# Altium schematic sheet GA-RELE-B.SchDoc(GA-RELE-B)
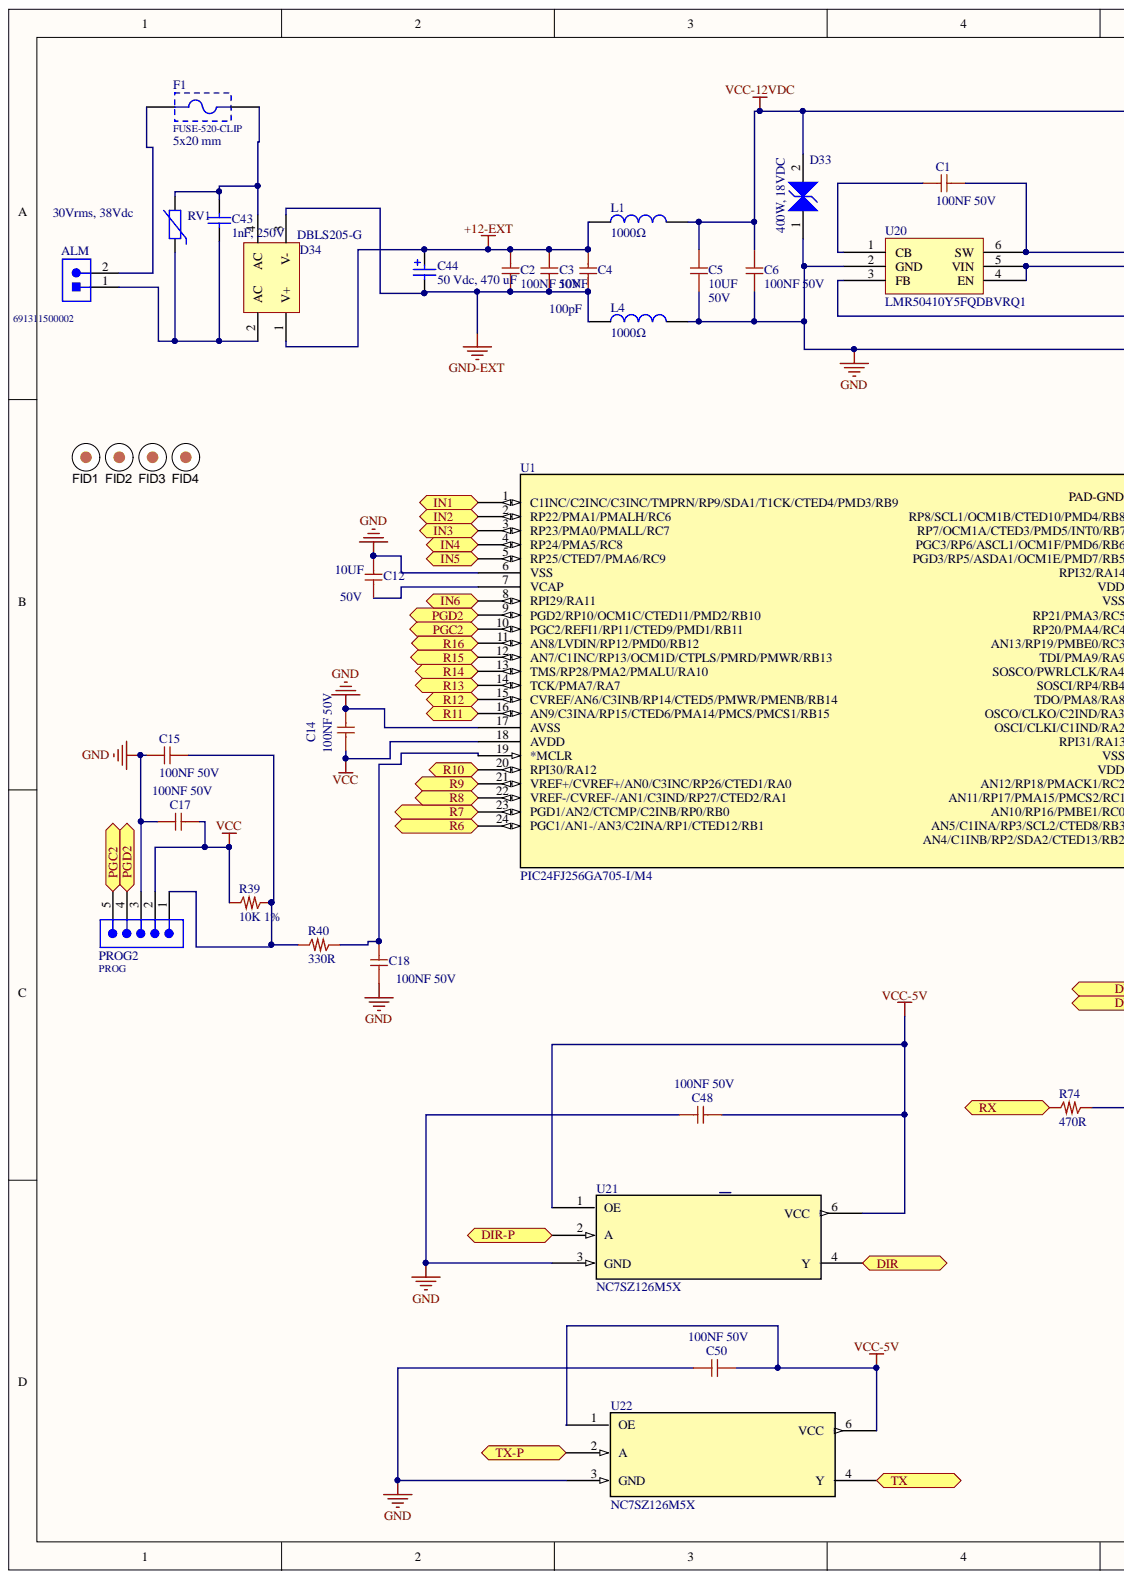
[diagram p0: GA-RELE-B.SchDoc, left]
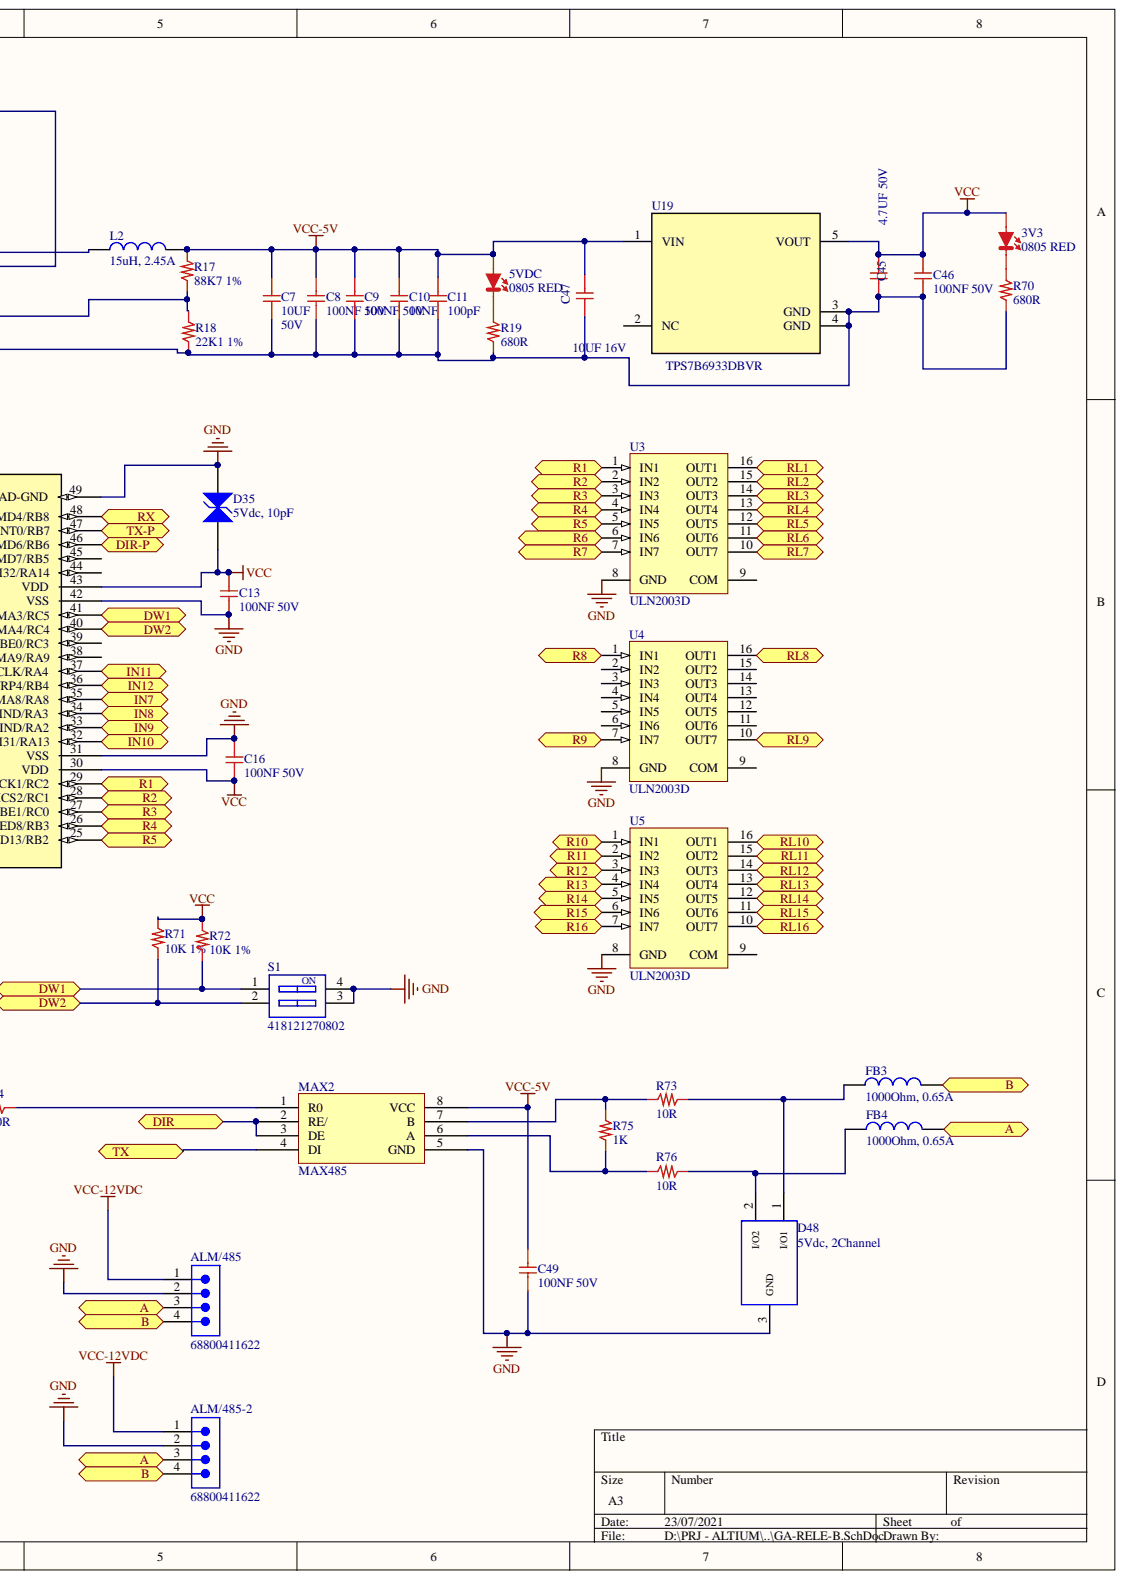
[diagram p1: GA-RELE-B.SchDoc, right]

## Parts
3V3  0805 RED  [0805_Red]
5VDC  0805 RED  [0805_Red]
ALM  691311500002  [691311500002]
ALM/485  68800411622  [68800411622]
ALM/485-2  68800411622  [68800411622]
C1  100NF 50V  [0603_c]
C2  100NF 50V  [0603_c]
C3  10NF  [0603_c]
C4  100pF  [0603_c]
C5  10UF  Tensione: 50V  [1206_C]
C6  100NF 50V  [0603_c]
C7  10UF  Tensione: 50V  [1206_C]
C8  100NF 50V  [0603_c]
C9  100NF 50V  [0603_c]
C10  10NF  [0603_c]
C11  100pF  [0603_c]
C12  10UF  Tensione: 50V  [1206_C]
C13  100NF 50V  [0603_c]
C14  100NF 50V  [0603_c]
C15  100NF 50V  [0603_c]
C16  100NF 50V  [0603_c]
C17  100NF 50V  [0603_c]
C18  100NF 50V  [0603_c]
C43  1nF, 250V  [WCAP-CSSA_1808_H=2mm]
C44  50 Vdc, 470 uF  value 470 uF  [WCAP-ATLI_13x20x5]
C45  4.7UF 50V  [0805_C]
C46  100NF 50V  [0603_c]
C47  10UF 16V  [0805_C]
C48  100NF 50V  [0603_c]
C49  100NF 50V  [0603_c]
C50  100NF 50V  [0603_c]
D33  400W, 18VDC  [WE-TVSP_DO-214AC_Bidirectional]
D34  DBLS205-G  [DBLS205-G]
D35  5Vdc, 10pF  Capacitance: 10pF  [WE-VE_0603]
D48  5Vdc, 2Channel  [WE-TVS_Standard_SOT23-3L]
F1  FUSE-520-CLIP  Fuse Size: 5x20 mm  [FUSE-520-CLIP]
FB3  1000Ohm, 0.65A  Rated Current: 0.65A  [WE-CBF_0603_Wide Band]
FB4  1000Ohm, 0.65A  Rated Current: 0.65A  [WE-CBF_0603_Wide Band]
FID1  Fiducial  [Fiducial10-20]  (value not drawn)
FID2  Fiducial  [Fiducial10-20]  (value not drawn)
FID3  Fiducial  [Fiducial10-20]  (value not drawn)
FID4  Fiducial  [Fiducial10-20]  (value not drawn)
L1  1000Ω  [WE-CBF_0805_Wide Band]
L2  15uH, 2.45A  Rated Current: 2.45A  [WE-LQS_6045]
L4  1000Ω  [WE-CBF_0805_Wide Band]
MAX2  MAX485  [SO-8]
PROG2  PROG  [PROG1 - TR]
R17  88K7 1%  [0603_R]
R18  22K1 1%  [0603_R]
R19  680R  [0603_R]
R39  10K 1%  [0603_R]
R40  330R  [0603_R]
R70  680R  [0603_R]
R71  10K 1%  [0603_R]
R72  10K 1%  [0603_R]
R73  10R  [0805_R]
R74  470R  [0603_R]
R75  1K  [0805_R]
R76  10R  [0805_R]
RV1  30Vrms, 38Vdc  DC Operating Voltage: 38Vdc  [WE-VD_7mm_820573001]
S1  418121270802  [418121270802]
U1  PIC24FJ256GA705-I/M4  [UQFN48_PTX-M4_MCH-L]  (value not drawn)
U3  ULN2003D  [SO16_N]
U4  ULN2003D  [SO16_N]
U5  ULN2003D  [SO16_N]
U19  TPS7B6933DBVR  [DBV0005A_N]
U20  LMR50410Y5FQDBVRQ1  [SOT23-6_N]
U21  NC7SZ126M5X  [SOT23-5_N]
U22  NC7SZ126M5X  [SOT23-5_N]

## Ports
A, B, DIR, DIR-P, DW1, DW2, IN1, IN2, IN3, IN4, IN5, IN6, IN7, IN8, IN9, IN10, IN11, IN12, PGC2, PGD2, R1, R2, R3, R4, R5, R6, R7, R8, R9, R10, R11, R12, R13, R14, R15, R16, RL1, RL2, RL3, RL4, RL5, RL6, RL7, RL8, RL9, RL10, RL11, RL12, RL13, RL14, RL15, RL16, RX, TX, TX-P

## Nets
+12-EXT: C2.1 C3.1 C4.1 C44.1 D34.1(V+) L1.1
GND: ALM/485.2 ALM/485-2.2 C5.2 C6.2 C7.2 C8.2 C9.2 C10.2 C11.2 C12.1 C13.2 C14.1 C15.1 C16.1 C17.1 C18.2 C45.2 C46.1 C47.2 C48.1 C49.1 C50.1 D33.1 D35.2 D48.3 L4.2 MAX2.5(GND) PROG2.3 R18.1 R19.2 R70.2 S1.3 S1.4 U1.6(VSS) U1.17(AVSS) U1.31(VSS) U1.42(VSS) U1.49(PAD-GND) U3.8(GND) U4.8(GND) U5.8(GND) U19.3(GND) U19.4(GND) U20.2(GND) U21.3(GND) U22.3(GND)
GND-EXT: C2.2 C3.2 C4.2 C44.2 D34.3(V-) L4.1
VCC: 3V3.A C13.1 C14.2 C16.2 C17.2 C45.1 C46.2 D35.1 PROG2.2 R39.1 R71.2 R72.2 U1.18(AVDD) U1.30(VDD) U1.43(VDD) U19.5(VOUT)
VCC-5V: 5VDC.A C7.1 C8.1 C9.1 C10.1 C11.1 C47.1 C48.2 C49.2 C50.2 L2.2 MAX2.8(VCC) R17.1 U19.1(VIN) U21.1(OE) U21.6(VCC) U22.1(OE) U22.6(VCC)
VCC-12VDC: ALM/485.1 ALM/485-2.1 C5.1 C6.1 D33.2 L1.2 U20.4(EN) U20.5(VIN)
A: ALM/485.3 ALM/485-2.3 FB4.2 ; port A
B: ALM/485.4 ALM/485-2.4 FB3.2 ; port B
DIR: MAX2.2(RE/) MAX2.3(DE) U21.4(Y) ; port DIR
DIR-P: U1.46(PGC3/RP6/ASCL1/OCM1F/PMD6/RB6) U21.2(A) ; port DIR-P
DW1: R72.1 S1.1 U1.41(RP21/PMA3/RC5) ; port DW1
DW2: R71.1 S1.2 U1.40(RP20/PMA4/RC4) ; port DW2
IN1: U1.1(C1INC/C2INC/C3INC/TMPRN/RP9/SDA1/T1CK/CTED4/PMD3/RB9) ; port IN1
IN2: U1.2(RP22/PMA1/PMALH/RC6) ; port IN2
IN3: U1.3(RP23/PMA0/PMALL/RC7) ; port IN3
IN4: U1.4(RP24/PMA5/RC8) ; port IN4
IN5: U1.5(RP25/CTED7/PMA6/RC9) ; port IN5
IN6: U1.8(RPI29/RA11) ; port IN6
IN7: U1.35(TDO/PMA8/RA8) ; port IN7
IN8: U1.34(OSCO/CLKO/C2IND/RA3) ; port IN8
IN9: U1.33(OSCI/CLKI/C1IND/RA2) ; port IN9
IN10: U1.32(RPI31/RA13) ; port IN10
IN11: U1.37(SOSCO/PWRLCLK/RA4) ; port IN11
IN12: U1.36(SOSCI/RP4/RB4) ; port IN12
PGC2: PROG2.5 U1.10(PGC2/REFI1/RP11/CTED9/PMD1/RB11) ; port PGC2
PGD2: PROG2.4 U1.9(PGD2/RP10/OCM1C/CTED11/PMD2/RB10) ; port PGD2
R1: U1.29(AN12/RP18/PMACK1/RC2) U3.1(IN1) ; port R1
R2: U1.28(AN11/RP17/PMA15/PMCS2/RC1) U3.2(IN2) ; port R2
R3: U1.27(AN10/RP16/PMBE1/RC0) U3.3(IN3) ; port R3
R4: U1.26(AN5/C1INA/RP3/SCL2/CTED8/RB3) U3.4(IN4) ; port R4
R5: U1.25(AN4/C1INB/RP2/SDA2/CTED13/RB2) U3.5(IN5) ; port R5
R6: U1.24(PGC1/AN1-/AN3/C2INA/RP1/CTED12/RB1) U3.6(IN6) ; port R6
R7: U1.23(PGD1/AN2/CTCMP/C2INB/RP0/RB0) U3.7(IN7) ; port R7
R8: U1.22(VREF-/CVREF-/AN1/C3IND/RP27/CTED2/RA1) U4.1(IN1) ; port R8
R9: U1.21(VREF+/CVREF+/AN0/C3INC/RP26/CTED1/RA0) U4.7(IN7) ; port R9
R10: U1.20(RPI30/RA12) U5.1(IN1) ; port R10
R11: U1.16(AN9/C3INA/RP15/CTED6/PMA14/PMCS/PMCS1/RB15) U5.2(IN2) ; port R11
R12: U1.15(CVREF/AN6/C3INB/RP14/CTED5/PMWR/PMENB/RB14) U5.3(IN3) ; port R12
R13: U1.14(TCK/PMA7/RA7) U5.4(IN4) ; port R13
R14: U1.13(TMS/RP28/PMA2/PMALU/RA10) U5.5(IN5) ; port R14
R15: U1.12(AN7/C1INC/RP13/OCM1D/CTPLS/PMRD/PMWR/RB13) U5.6(IN6) ; port R15
R16: U1.11(AN8/LVDIN/RP12/PMD0/RB12) U5.7(IN7) ; port R16
RL1: U3.16(OUT1) ; port RL1
RL2: U3.15(OUT2) ; port RL2
RL3: U3.14(OUT3) ; port RL3
RL4: U3.13(OUT4) ; port RL4
RL5: U3.12(OUT5) ; port RL5
RL6: U3.11(OUT6) ; port RL6
RL7: U3.10(OUT7) ; port RL7
RL8: U4.16(OUT1) ; port RL8
RL9: U4.10(OUT7) ; port RL9
RL10: U5.16(OUT1) ; port RL10
RL11: U5.15(OUT2) ; port RL11
RL12: U5.14(OUT3) ; port RL12
RL13: U5.13(OUT4) ; port RL13
RL14: U5.12(OUT5) ; port RL14
RL15: U5.11(OUT6) ; port RL15
RL16: U5.10(OUT7) ; port RL16
RX: R74.1 U1.48(RP8/SCL1/OCM1B/CTED10/PMD4/RB8) ; port RX
TX: MAX2.4(DI) U22.4(Y) ; port TX
TX-P: U1.47(RP7/OCM1A/CTED3/PMD5/INT0/RB7) U22.2(A) ; port TX-P
Net3V3_K: 3V3.K R70.1
Net5VDC_K: 5VDC.K R19.1
NetALM_1: ALM.1 C43.1 D34.2(AC) RV1.1
NetALM_2: ALM.2 F1.1
NetC1_1: C1.1 U20.1(CB)
NetC1_2: C1.2 L2.1 U20.6(SW)
NetC12_2: C12.2 U1.7(VCAP)
NetC15_2: C15.2 PROG2.1 R39.2 R40.2
NetC18_1: C18.1 R40.1 U1.19(*MCLR)
NetC43_2: C43.2 D34.4(AC) F1.2 RV1.2
NetD48_1: D48.1 FB3.1 R73.2
NetD48_2: D48.2 FB4.1 R76.2
NetMAX2_1: MAX2.1(R0) R74.2
NetMAX2_6: MAX2.6(A) R75.1 R76.1
NetMAX2_7: MAX2.7(B) R73.1 R75.2
NetR17_2: R17.2 R18.2 U20.3(FB)
N00004:

Not connected: U1 38(TDI/PMA9/RA9) 39(AN13/RP19/PMBE0/RC3) 44(RPI32/RA14) 45(PGD3/RP5/ASDA1/OCM1E/PMD7/RB5); U3 9(COM); U4 2(IN2) 3(IN3) 4(IN4) 5(IN5) 6(IN6) 9(COM) 11(OUT6) 12(OUT5) 13(OUT4) 14(OUT3) 15(OUT2); U5 9(COM); U19 2(NC)

## Library data of the parts
3V3 5VDC = LED_ROSSO_0805: LED Rosso 0805; V: 2.0V; Produttore: WE; Luminosità: 150mcd; Cod. Produttore: 150080RS75000; Cod. Interno: LEDS 2AC080RS7500; Component Kind: Standard; Library Name: DiodiLED.SchLib
ALM = 691311500002: Serie 311 - 5.08mm Open Vertical PCB Header WR-TBL, 2 pin; Pin Number: 2; Gender: Male; Published Date: July 2018; Pitch: 5.08 mm; Part Number: 691311500002; Packaging: Box; Operating Temperature: -40 to 105 degC; Mounting Technology: THT; Library Name: Pluggable PCB System WR-TBL_5.08 mm.SchLib; ComponentLink2URL: http://katalog.we-online.de/em/datasheet/6913115000xx.pdf; ComponentLink2Description: Datasheet; ComponentLink1URL: http://www.we-online.de; ComponentLink1Description: Manufacturer; Component Kind: Standard; Publisher: Wurth Elektronik
ALM/485 ALM/485-2 = 68800411622: WR-WTB “J” Type THT Vertical Male Shrouded Header, pitch 2.5mm, 4p; Working Voltage: 250VAC; Withstanding Voltage: 800VAC; Rated Current: 3A; Pin Number: 4; Contact Resistance: 20mOhm; Type: Vertical; Published Date: January 2020; Pitch: 2.5mm; Part Number: 68800411622; Packaging: Bag; Operating Temperature: -25 to +85degC; Mounting Technology: THT; Library Name: WR-WTB_2.50mm.SchLib; Gender: Male; ComponentLink2URL: https://www.we-online.com/catalog/datasheet/68800411622.pdf; ComponentLink2Description: Datasheet; ComponentLink1URL: http://www.we-online.de; ComponentLink1Description: Manufacturer; Component Kind: Standard; Publisher: Wurth Elektronik
C1 C2 C6 C8 C9 C13-C18 C46 C48-C50 = 100NF 50V: Cap X7R 100nF 50V Flex Terminal; Produttore: Samsung; Cod. Produttore: CL10B104KB8SFNC; Cod. Interno: COCS50665050104; Library Name: CapSMD0603.SchLib; Component Kind: Standard; Cod. DigiKey: 1276-1936-2-ND
C3 C10 = 10NF 50V: Cap X7R 10nF 50V; Produttore: Samsung; Cod. Produttore: CL05B103KB5NNNC; Cod. Interno: COCS 556655000103; Library Name: CapSMD0603.SchLib; Component Kind: Standard; Cod. DigiKey: 1276-1028-2-ND
C4 C11 = 100pF 50V: Cap X7R 100pF 50V; Produttore: ??; Cod. Interno: COCS??; Library Name: CapSMD0603.SchLib; Component Kind: Standard; Cod. Produttore: ??
C5 C7 C12 = 10UF 50V: Cap X5R 10UF 50V; Produttore: MURATA; Cod. Produttore: GRM31CR61H106KA12L; Temperature Range: Da -55°C a +125°C; Library Name: CapSMD1206.SchLib; Component Kind: Standard; Cod. Farnell: 2666583
C43 = 885362210017: WCAP-CSSA X&Y Safety Ceramic Capacitors, size 1808, 1nF, 250VAC; Technical Reference: X7R1808102KB50IFCT10000; Safety Class: X2; Isolation Resistance: 10GOhm; Dissipation Factor: 2.5%; Ceramic Type: X7R; Size: 1808; Rated Voltage: 250VAC; Published Date: August 2019; Part Number: 885362210017; Packaging: Tape and Reel; Operating Temperature: -55 to +125degC; Mounting Technology: SMD; Library Name: WCAP-CSSA_1808.SchLib; Height: 2mm +/-0.2mm; ComponentLink2URL: https://katalog.we-online.com/pbs/datasheet/885362210017.pdf; ComponentLink2Description: Datasheet; ComponentLink1URL: http://www.we-online.de; ComponentLink1Description: Manufacturer; Component Kind: Standard; Capacitance: 1nF; Publisher: Wurth Elektronik
C44 = 860080678019: WCAP-ATLI Aluminum Electrolytic Capacitors, 13x20mm, 50 Vdc, 470 uF; Value: 470 uF; Rated Voltage: 50 Vdc; Size: 13x20mm; Published Date: Sep. 2017; Part Number: 860080678019; Operating Temperature: -55 °C up to +105 °C; Life Cycle: 5000h; Library Name: WCAP-ATLI.SchLib; ComponentLink2URL: http://katalog.we-online.com/pbs/datasheet/860080678019.pdf; ComponentLink2Description: Datasheet; ComponentLink1URL: http://www.we-online.de; ComponentLink1Description: Manufacturer; Component Kind: Standard; Publisher: Wurth Elektronik
C45 = 4.7UF 50V: Cap X5R 4.7UF 50V; Produttore: TDK; Cod. Produttore: C2012X5R1H475K; Cod. Interno: COCS517065000475; Temperature Range: Da -55°C a +105°C; Library Name: CapSMD0805.SchLib; Component Kind: Standard
C47 = 10UF 16V: Cap X5R 10UF 16V; Produttore: TDK; Cod. Produttore: C2012X6S1C106K125AC; Cod. Interno: COCS517061600106; Temperature Range: Da -55°C a +105°C; Library Name: CapSMD0805.SchLib; Component Kind: Standard; Cod. DigiKey: 445-7611-2-ND
D33 = 824501181: WE-TVSP Power TVS Diode, DO-214AC, 400W, 18VDC, Bidirectional; DC Operating Voltage: 18V; Clamping Voltage: 29.2V; (Reverse) Breakdown Voltage: 21.05V; (Forward) Peak Pulse Current: 13.7A; Steady State Power Dissipation: 400W; Size: DO-214AC; Published Date: March 2019; Polarity: Bidirectional; Part Number: 824501181; Packaging: Tape and Reel; Operating Temperature: -65 to +150degC; Mounting Technology: SMT; Library Name: WE-TVSP_DO-214AC_Bidirectional.SchLib; ComponentLink2URL: https://katalog.we-online.com/pbs/datasheet/824501181.pdf; ComponentLink2Description: Datasheet; ComponentLink1URL: http://www.we-online.de; ComponentLink1Description: Manufacturer; Component Kind: Standard; Publisher: Wurth Elektronik
D34 = DBLS205-G: Diode Bridge; Simulation: BRIDGE; Published: 24-Mar-1999; PackageReference: D-46_6A; PackageDescription: Bridge Rectifier; 4 Terminals; Body 16.8 x 16.8 mm (typ); Pitch 10.67 mm; LatestRevisionNote: Re-released for DXP Platform.; LatestRevisionDate: 17-Jul-2002; Component Kind: Standard; Publisher: Altium Limited; Library Name: HSW.SchLib
D35 = 82356050100: WE-VE ESD Suppressor, size 0603, 5Vdc, 10pF; Leakage Current: 1uA; ESD Voltage air discharge: 15kV; Clamp Voltage: 60V; Working Voltage: 5Vdc; Size: 0603; Published Date: June 2019; Part Number: 82356050100; Packaging: Tape and Reel; Operating Temperature: -40 to +85degC; Mounting Technology: SMT; Library Name: WE-VE_0603.SchLib; ComponentLink2URL: https://katalog.we-online.com/pbs/datasheet/82356050100.pdf; ComponentLink2Description: Datasheet; ComponentLink1URL: http://www.we-online.de; ComponentLink1Description: Manufacturer; Component Kind: Standard; Publisher: Wurth Elektronik
D48 = 824022: WE-TVS TVS Diode - Standard Series, SOT23-3L, 5Vdc, 2 Channel; Reverse Peak Pulse Current: 8A; Reverse Channel Leakage Current: 2.5uA; Reverse Breakdown Voltage min.: 6.1V; DC Operating Voltage: 5V; Channel Input Capacitance: 12pF; Channel: 2; Size: SOT23-3L; Published Date: June 2019; Part Number: 824022; Packaging: Tape and Reel; Operating Temperature: -55 to +125degC; Mounting Technology: SMT; Library Name: WE-TVS.SchLib; ComponentLink2URL: https://katalog.we-online.com/pbs/datasheet/824022.pdf; ComponentLink2Description: Datasheet; ComponentLink1URL: http://www.we-online.de; ComponentLink1Description: Manufacturer; Component Kind: Standard; Publisher: Wurth Elektronik
F1 = FUSE-520-CLIP: LITTELFUSE-520 Series Circuit Board Mount Fuse Clips for 5mm & 6.3mm Diameter Fuses; Part Number: 696108003002; Published Date: August 2018; Packaging: Box; Operating Temperature: -30 to 120 degC; Mounting Technology: THT; ComponentLink2URL: https://katalog.we-online.de/em/datasheet/696108003002.pdf; ComponentLink2Description: Datasheet; ComponentLink1URL: http://www.we-online.de; ComponentLink1Description: Manufacturer; Component Kind: Standard; Publisher: Wurth Elektronik; Library Name: HSW.SchLib
FB3 FB4 = 74279266: WE-CBF SMT EMI Suppression Ferrite Bead, size 0603, 1000Ohm, 0.65A; Type: Wide Band; Impedance max.: 1350Ohm; DC Resistance max.: 0.6Ohm; Size: 0603; Published Date: March 2019; Part Number: 74279266; Packaging: Tape and Reel; Operating Temperature: -55 to +125degC; Mounting Technology: SMT; Library Name: WE-CBF_0603.SchLib; Impedance@100 MHz: 1000Ohm; ComponentLink2URL: https://katalog.we-online.com/pbs/datasheet/74279266.pdf; ComponentLink2Description: Datasheet; ComponentLink1URL: http://www.we-online.de; ComponentLink1Description: Manufacturer; Component Kind: Standard; Publisher: Wurth Elektronik
FID1-FID4 = Fiducial: Fiducial mark.  There is nothing to buy or mount.; SymbolCreator: codlsc; SymbolVersion: 2009-11-4; PartNumber: N/A; PackageReference: N/A; MountType: N/A; Manufacturer: N/A; LatestRevisionNote: Added Alternate PartNumber and Alternate Manufacturer parameters to appropriate components.; LatestRevisionDate: 2015-10-18; CompType: Fiducial; Component Kind: Standard; ClassName: FiducialMark; Publisher: Texas Instruments; Library Name: HSW.SchLib
L1 L4 = WE-CBF, 74279205: WE-CBF SMD EMI Suppression Ferrite Bead, 0805, 1000Ω; Type: Wide Band; Value: 1000Ω; Size: 0805; PublishedDate: Aug 2016; PartNumber: 74279205; Library Name: WE-CBF_0805.SchLib; ComponentLink2URL: http://katalog.we-online.de/pbs/datasheet/74279205.pdf; ComponentLink2Description: Datasheet; ComponentLink1URL: http://www.we-online.de; ComponentLink1Description: Manufacturer; Component Kind: Standard; Publisher: Wurth Elektronik
L2 = 74404064150: WE-LQS SMT Power Inductor, size 6045, 15uH, 2.45A; Manufacturer: Wurth Elektronik; Self Resonant Frequency: 17MHz; Saturation Current: 3A; DC Resistance: 68mOhm; Size: 6045; Published Date: March 2020; Packaging: Tape and Reel; Operating Temperature: -40 to +125degC; Mounting Technology: SMT; Manufacturer Part Number: 74404064150; Library Name: WE-LQS_6045.SchLib; Inductance: 15uH; ComponentLink2URL: https://www.we-online.com/catalog/datasheet/74404064150.pdf; ComponentLink2Description: Datasheet; ComponentLink1URL: http://www.we-online.de; ComponentLink1Description: Manufacturer; Component Kind: Standard
PROG2 = PROG1-TR: SMT Vertical Pin Header WR-PHD, Pitch 2.54 mm, Single Row, 6 pins; Part Number: 61000618321; Working Voltage: 250 VAC; Withstanding Voltage: 500 VAC; Rated Current: 3 A; Pitch: 2.54 mm; Pin Number: 6; Gender: Male; Contact Resistance: 20 mOhm; Row Number: Single; Published Date: August 2018; Packaging: Tube; Orientation: Vertical; Operating Temperature: -40 to 125 degC; Mounting Technology: SMT; ComponentLink2URL: https://katalog.we-online.de/em/datasheet/6100xx18321.pdf; ComponentLink2Description: Datasheet; ComponentLink1URL: http://www.we-online.de; ComponentLink1Description: Manufacturer; Component Kind: Standard; Publisher: Wurth Elektronik; Library Name: HSW.SchLib
R17 = 88K7 1%: Res Film Spesso 1/16W 88K7 1%; Library Name: ResSMD0603.SchLib; Component Kind: Standard
R18 = 22K1 1%: Res Film Spesso 1/16W 22K1 1%; Produttore: ??; Library Name: ResSMD0603.SchLib; Component Kind: Standard; Cod. Produttore: ??
R19 R70 = 680R: Res Film Spesso 1/16W 680R 5%; Cod. Interno: RESS 4166556B0681; Library Name: ResSMD0603.SchLib; Component Kind: Standard
R39 R71 R72 = 10K 1%: Res Film Spesso 1/16W 10K 1%; Produttore: Panasonic; Cod. Produttore: ERJ-3EKF1002V; Cod. Interno: RESS4166356B0103; Library Name: ResSMD0603.SchLib; Component Kind: Standard
R40 = 330R: Res Film Spesso 1/16W 330R 5%; Cod. Interno: RESS4166556B0331; Library Name: ResSMD0603.SchLib; Component Kind: Standard
R73 R76 = 10R: Res Film Spesso 1/8W 10R 5%; Potenza: 1/8W 5%; Cod. Interno: RESS4170555B0100; Library Name: ResSMD0805.SchLib; Component Kind: Standard
R74 = 470R: Res Film Spesso 1/16W 470R 5%; Produttore: ??; Cod. Interno: RESS4166556B0471??; Library Name: ResSMD0603.SchLib; Component Kind: Standard; Cod. Produttore: ??
R75 = 1K: Res Film Spesso 1/2W 1K 5%; Potenza: 1/2W 5%; Cod. Interno: RESS4170555B0122??; Library Name: ResSMD0805.SchLib; Component Kind: Standard
RV1 = 820573001: WE-VD Disk Varistor, size 7mm, 30Vrms, 38Vdc; Power Dissipation: 0.02W; Energy Absorbtion: 3J; Clamping Voltage: 93V; (Reverse) Peak Pulse Current: 250A; (Reverse) Breakdown Voltage: 47V; (Channel) Input Capacitance: 1600pF; Size: 7mm; Published Date: March 2019; Part Number: 820573001; Packaging: Tape and Reel; Operating Temperature: -40 to +85degC; Mounting Technology: THT; ComponentLink2URL: https://katalog.we-online.com/pbs/datasheet/820573001.pdf; ComponentLink2Description: Datasheet; ComponentLink1URL: http://www.we-online.de; ComponentLink1Description: Manufacturer; Component Kind: Standard; AC Operating Voltage: 30Vrms; Publisher: Wurth Elektronik; Library Name: MOV_7mm.SchLib
S1 = 418121270802: SMD Dip Switch WS-DISV, Small Compact, Raised Actuator, pitch 2.54mm, 2pin; Pole Number: 2; Operation Force: 600g max.; Actuator Type: Raise Type; Published Date: April 2019; Pitch: 2.54mm; Part Number: 418121270802; Packaging: Tape and Reel; Operating Temperature: -40 to +85degC; Mounting Technology: SMT; ComponentLink2URL: https://katalog.we-online.com/em/datasheet/418121270802.pdf; ComponentLink2Description: Datasheet; ComponentLink1URL: http://www.we-online.de; ComponentLink1Description: Manufacturer; Component Kind: Standard; Publisher: Wurth Elektronik; Library Name: DIPSMD WS-DISV.SchLib
U1 = PIC24FJ256GA705-I/M4: 16-Bit General Purpose Microcontrollers with 256-Kbyte Flash and 16-Kbyte RAM in Low Pin Count Packages; Vendor: Microchip; SourceLibrary: Add_to_database_2020-11-20; Built_By: EMA_Cory; Type: DEV; RefDes: RefDes; Manufacturer_Part_Number: PIC24FJ256GA705-I/M4; Datasheet: https://ww1.microchip.com/downloads/en/DeviceDoc/PIC24FJ256GA705-Family-Data-Sheet-DS30010118E.pdf; Component Kind: Standard; Library Name: HSW.SchLib; Copyright: Copyright (C) 2018 Accelerated Designs. All rights reserved
U3-U5 = ULN2003D: Seven Darlington Array; Published: 8-Jun-2000; PackageVersion: May-1998; PackageReference: SO16; PackageDescription: 16-Pin Small Outline Integrated Circuit 1.27 mm Pitch; LatestRevisionNote: IPC-7351 Footprint Added.; LatestRevisionDate: 13-Apr-2006; DatasheetVersion: Sep-1998; ComponentLink2URL: http://www.st.com/stonline/products/literature/ds/5279/uln2001.pdf; ComponentLink2Description: Datasheet; ComponentLink1URL: http://www.st.com/; ComponentLink1Description: Manufacturer Link; Component Kind: Standard; Code_IPC: SOIC127P600-16; Publisher: Altium Limited; Library Name: HSW.SchLib
U19 = TPS7B6933DBVR: TPS7B69xx150-mA,40-V Ultralow-Quiescent-CurrentLDO; Manufacturer: Texas Instruments; Value: Value; Type: DEV; RefDes: RefDes; PartNumber: TPS7B6933DBVR; Component Kind: Standard; Library Name: HSW.SchLib; Copyright: Copyright (C) 2018 Accelerated Designs. All rights reserved
U20 = LMR50410Y5FQDBVRQ1: 4 TO 36VDC IN 1A BUCK CONVERTER 5VOUT; Supplier Part Number 1: 2064681; Supplier 1: Farnell; Component Kind: Standard; Library Name: HSW.SchLib
U21 U22 = NC7SZ126M5X: No Description Available; Manufacturer_Part_Number: SN74LVC1G125DBVR; Vendor: Texas Instruments; Built_By: EMA_UL_Team; Value: Value; Type: DEV; RefDes: RefDes; Component Kind: Standard; Library Name: HSW.SchLib; Copyright: Copyright (C) 2018 Accelerated Designs. All rights reserved
MAX2 = MAX485: Component Kind: Standard; Library Name: HSW.SchLib
